# Altium schematic sheet USB_Power_3V3_Regulator.SchDoc
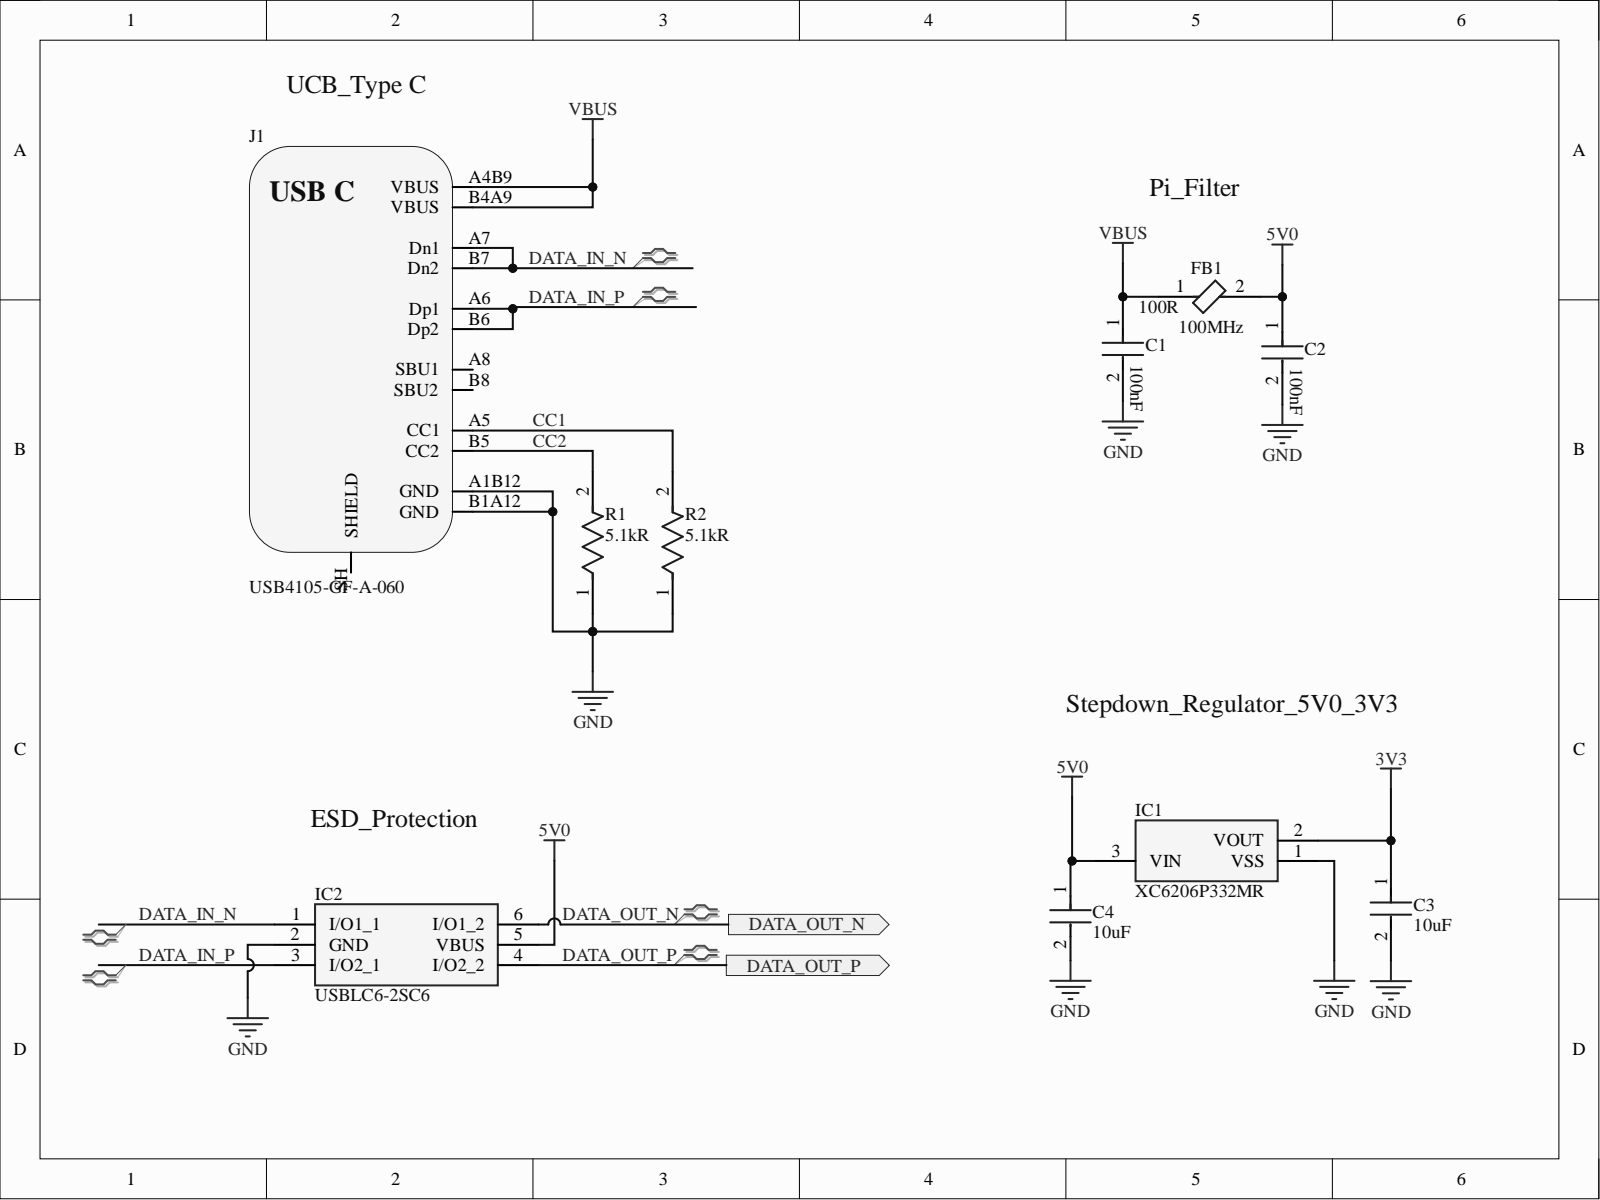
[diagram p0: USB_Power_3V3_Regulator.SchDoc]

## Parts
C1  Capacitance: 100nF  [FP-CL46-IPC_C]  (hidden comment: CL05A104KP5NNNC)
C2  Capacitance: 100nF  [FP-CL46-IPC_C]  (hidden comment: CL05A104KP5NNNC)
C3  Capacitance: 10uF  [FP-1206-L_3_2_0_2-W_1_6_0-IPC_A]  (hidden comment: 1206ZD106KAT2A)
C4  Capacitance: 10uF  [FP-1206-L_3_2_0_2-W_1_6_0-IPC_A]  (hidden comment: 1206ZD106KAT2A)
FB1  Impedance: 100R  Test Frequency: 100MHz  [FP-BLM18-0_15-t0_5-MFG]  (hidden comment: BLM18EG101TN1D)
IC1  XC6206P332MR  [SOT95P280X130-3N]
IC2  USBLC6-2SC6  [SOT95P280X145-6N]
J1  USB4105-GF-A-060  [USB4105-GF-A-060]
R1  Resistance: 5.1kR  [FP-CRCW0402-e3-IPC_A]  (hidden comment: CRCW04025K10FKED)
R2  Resistance: 5.1kR  [FP-CRCW0402-e3-IPC_A]  (hidden comment: CRCW04025K10FKED)

## Ports
DATA_OUT_N, DATA_OUT_P

## Nets
3V3: C3.1 IC1.2
5V0: C2.1 C4.1 FB1.2 IC1.3 IC2.5(VBUS)
GND: C1.2 C2.2 C3.2 C4.2 IC1.1 IC2.2(GND) J1.A1B12(GND) J1.B1A12(GND) R1.1 R2.1
VBUS: C1.1 FB1.1 J1.A4B9(VBUS) J1.B4A9(VBUS)
CC1: J1.A5(CC1) R2.2
CC2: J1.B5(CC2) R1.2
DATA_IN_N: IC2.1(I/O1_1) J1.A7(Dn1) J1.B7(Dn2)
DATA_IN_P: IC2.3(I/O2_1) J1.A6(Dp1) J1.B6(Dp2)
DATA_OUT_N: IC2.6(I/O1_2) ; port DATA_OUT_N
DATA_OUT_P: IC2.4(I/O2_2) ; port DATA_OUT_P

Not connected: J1 A8(SBU1) B8(SBU2) SH(SHIELD)

## Library data of the parts
C1 C2 = CMP-13271-002143-1: Cap Ceramic 100nF 10V X5R ±10% SMD 0402 +85°C Paper T/R; Case Code (Imperial): 0402; Case Code (Metric): 1005; Case/Package: 0402; Component Kind: Standard; Contact Plating: Tin; Depth: 0.5mm; Dielectric: X5R; Height: 0.5mm; Length: 1mm; Library Name: Altium Content Vault; Max Operating Temperature: 85°C; Min Operating Temperature: -55°C; Mount: Surface Mount; Packaging: Tape and Reel; Radiation Hardening: No; Thickness: 0.022inch; Tolerance: 10%; Voltage Rating: 10V; Width: 0.02inch
C3 C4 = CMP-04427-021870-1: General Purpose Ceramic Capacitor, 1206, 10uF, 10%, X5R, 15%, 10V; Case Code (Imperial): 1206; Case Code (Metric): 3216; Case/Package: 1206; Component Kind: Standard; Construction: Flat; Contact Plating: Tin; Depth: 1.6mm; Dielectric: X5R; Height: 0.07mm; Lead Free: Lead Free; Length: 3.2mm; Library Name: Altium Content Vault; Max Operating Temperature: 85°C; Min Operating Temperature: -55°C; Mount: Surface Mount; Number of Pins: 2; Package Quantity: 1; Packaging: Tape and Reel; Radiation Hardening: No; REACH SVHC: No SVHC; RoHS Compliant: Yes; Series: -; Size: 1.78mm; Termination: SMD/SMT; Thickness: 0.07inch; Tolerance: 10%; Voltage: 10V; Voltage Rating: 10V; Voltage Rating (DC): 10V; Width: 0.063inch
FB1 = CMP-0686-00530-3: Chip Ferrite Bead, 0603, 100Ω @ 100MHz, 25%, 0.045Ω, 2A; DC Resistance (DCR): 45mR; Number of Circuits: 1; Case Code (Imperial): 0603; Case Code (Metric): 1608; Case/Package: 0603; Component Kind: Standard; Contact Plating: Tin; Core Material: Ferrite; Current Rating: 2A; Depth: 0.8mm; Height: 0.8mm; Lead Free: Lead Free; Length: 1.6mm; Library Name: Altium Content Vault; Material: Ferrite; Max Current Rating: 2A; Max DC Current: 2A; Max Operating Temperature: 125°C; Min Operating Temperature: -55°C; Number of Lines: 1; Number of Pins: 2; Package Quantity: 1; Packaging: Tape and Reel; REACH SVHC: No SVHC; Termination: SMD/SMT; Tolerance: 25%; Width: 0.8mm
IC1 = XC6206P332MR: Integrated Circuit; Manufacturer_Name: Torex; Component Kind: Standard; Datasheet Link: http://www.torexsemi.com/products/voltage_regulators/data/XC6206.pdf; Height: 1.3mm; Library Name: XC6206P332MR.IntLib; Manufacturer_Part_Number: XC6206P332MR; Mouser Part Number: N/A; Mouser Price/Stock: https://www.mouser.co.uk/ProductDetail/Torex-Semiconductor/XC6206P332MR?qs=TiOZkKH1s2Qxu69VLzzkSQ%3D%3D
IC2 = USBLC6-2SC6: Integrated Circuit; Manufacturer_Name: STMicroelectronics; Arrow Part Number: USBLC6-2SC6; Arrow Price/Stock: https://www.arrow.com/en/products/usblc6-2sc6/stmicroelectronics?utm_currency=USD&region=europe; Component Kind: Standard; Datasheet Link: http://www.st.com/st-web-ui/static/active/en/resource/technical/document/datasheet/CD00050750.pdf; Height: 1.45mm; Library Name: USBLC6-2SC6.IntLib; Manufacturer_Part_Number: USBLC6-2SC6; Mouser Part Number: 511-USBLC6-2SC6; Mouser Price/Stock: https://www.mouser.co.uk/ProductDetail/STMicroelectronics/USBLC6-2SC6?qs=po45yt2pPpu%2FhNIlwQdTlg%3D%3D
J1 = USB4105-GF-A-060: USB Connector Type C SMT 12 Pin; Manufacturer: GCT; Component Kind: Standard; Distributor Link: https://eu.mouser.com/ProductDetail/GCT/USB4105-GF-A-060?qs=QNEnbhJQKvY2mocwNJzgfA%3D%3D; Manufacturer Part Number: USB4105-GF-A-060; Library Name: Schematic-Lib-PhilsLab.IntLib
R1 R2 = CMP-1011-00590-4: RES Thick Film, 5.1kΩ, 1%, 0.063W, 100ppm/°C, 0402; Max Power Dissipation: 63mW; Voltage Rating: 50V; Case Code (Imperial): 0402; Case Code (Metric): 1005; Case/Package: 0402; Component Kind: Standard; Composition: Thick Film; Contact Plating: Tin; Depth: 0.5mm; Features: Automotive AEC-Q200; Height: 0.35mm; Lead Free: Lead Free; Length: 1mm; Library Name: Altium Content Vault; Max Operating Temperature: 155°C; Military Standard: Not; Min Operating Temperature: -55°C; Number of Terminations: 2; Package Quantity: 10; Packaging: Tape and Reel; Power Rating: 63mW; Radiation Hardening: No; Temperature Coefficient: 100ppm/°C; Termination: SMD/SMT; Tolerance: 1%; Voltage Rating (DC): 50V; Weight: 2.3E-05oz; Width: 0.02inch
